# Altium schematic sheet 01 POWER.SchDoc
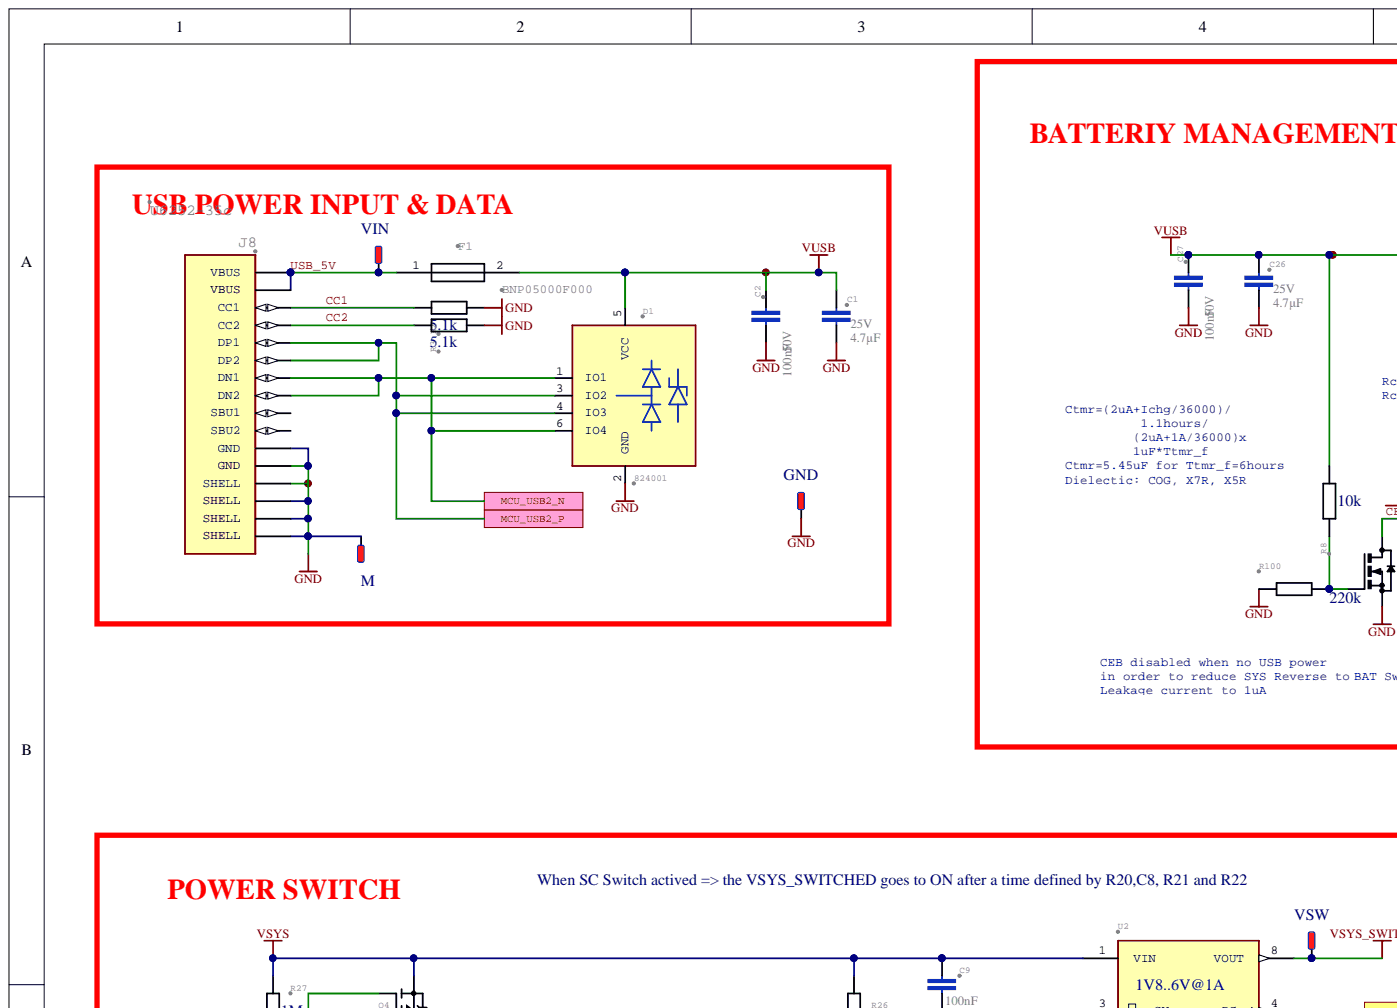
[diagram p0: 01 POWER.SchDoc, top left]
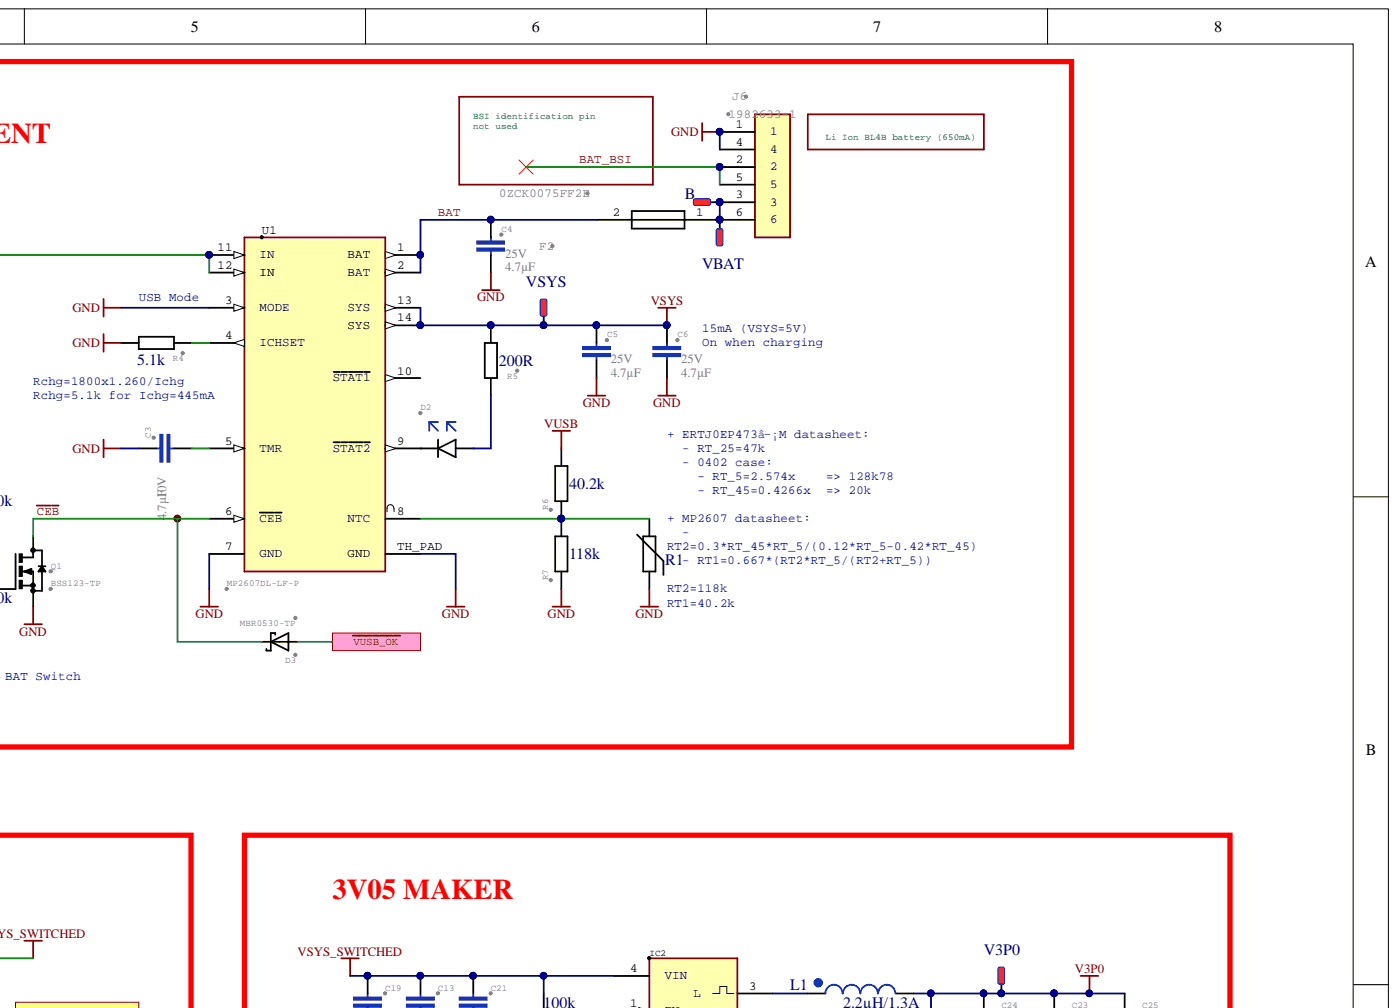
[diagram p1: 01 POWER.SchDoc, top right]
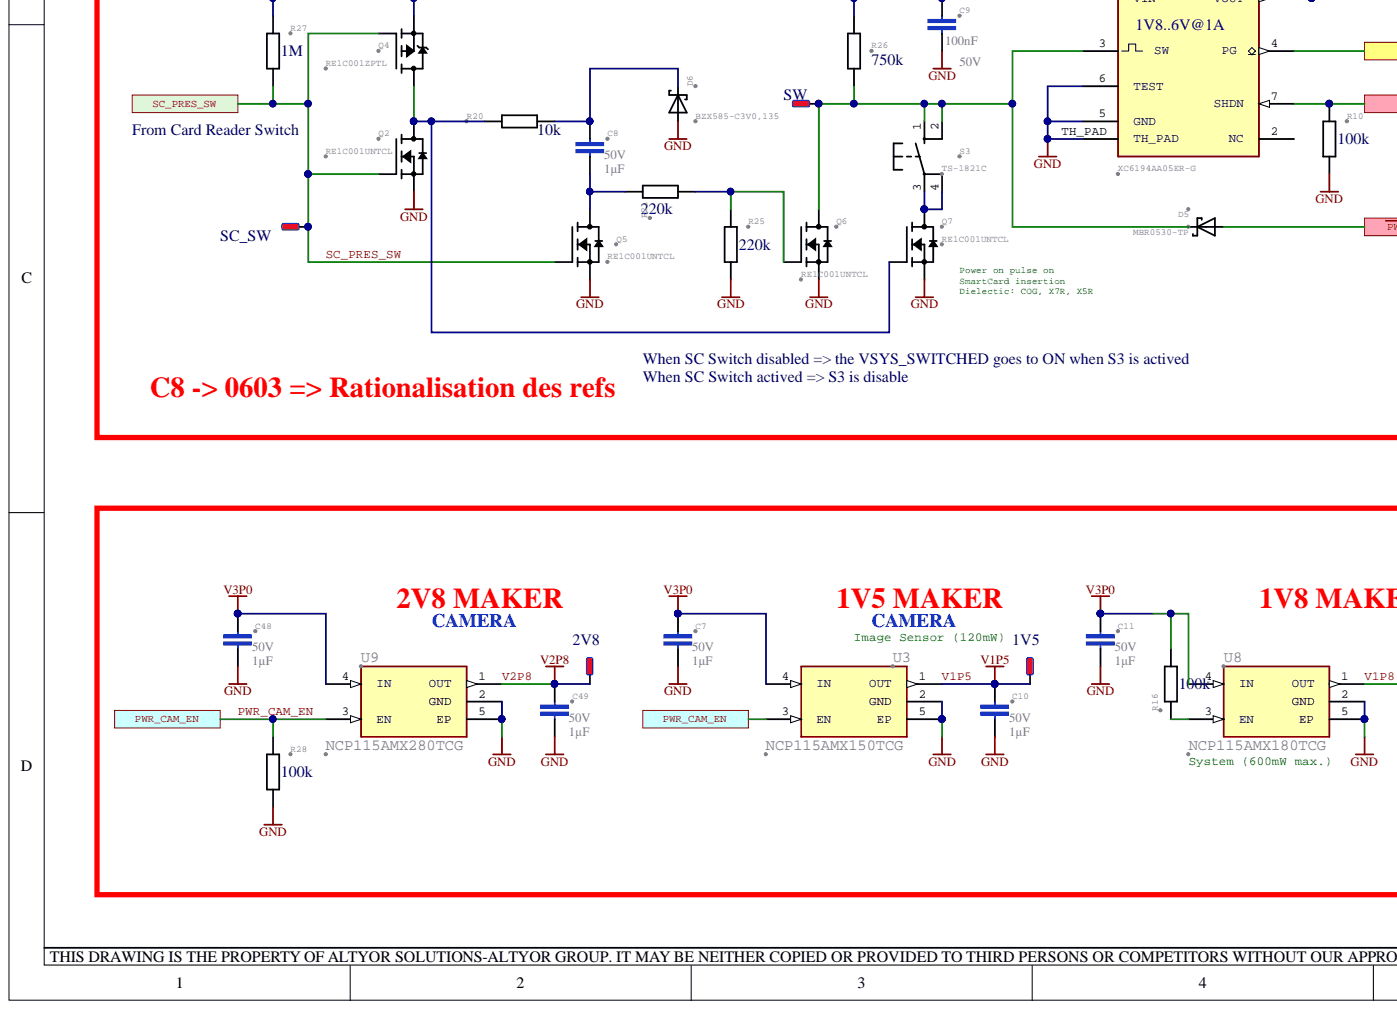
[diagram p2: 01 POWER.SchDoc, bottom left]
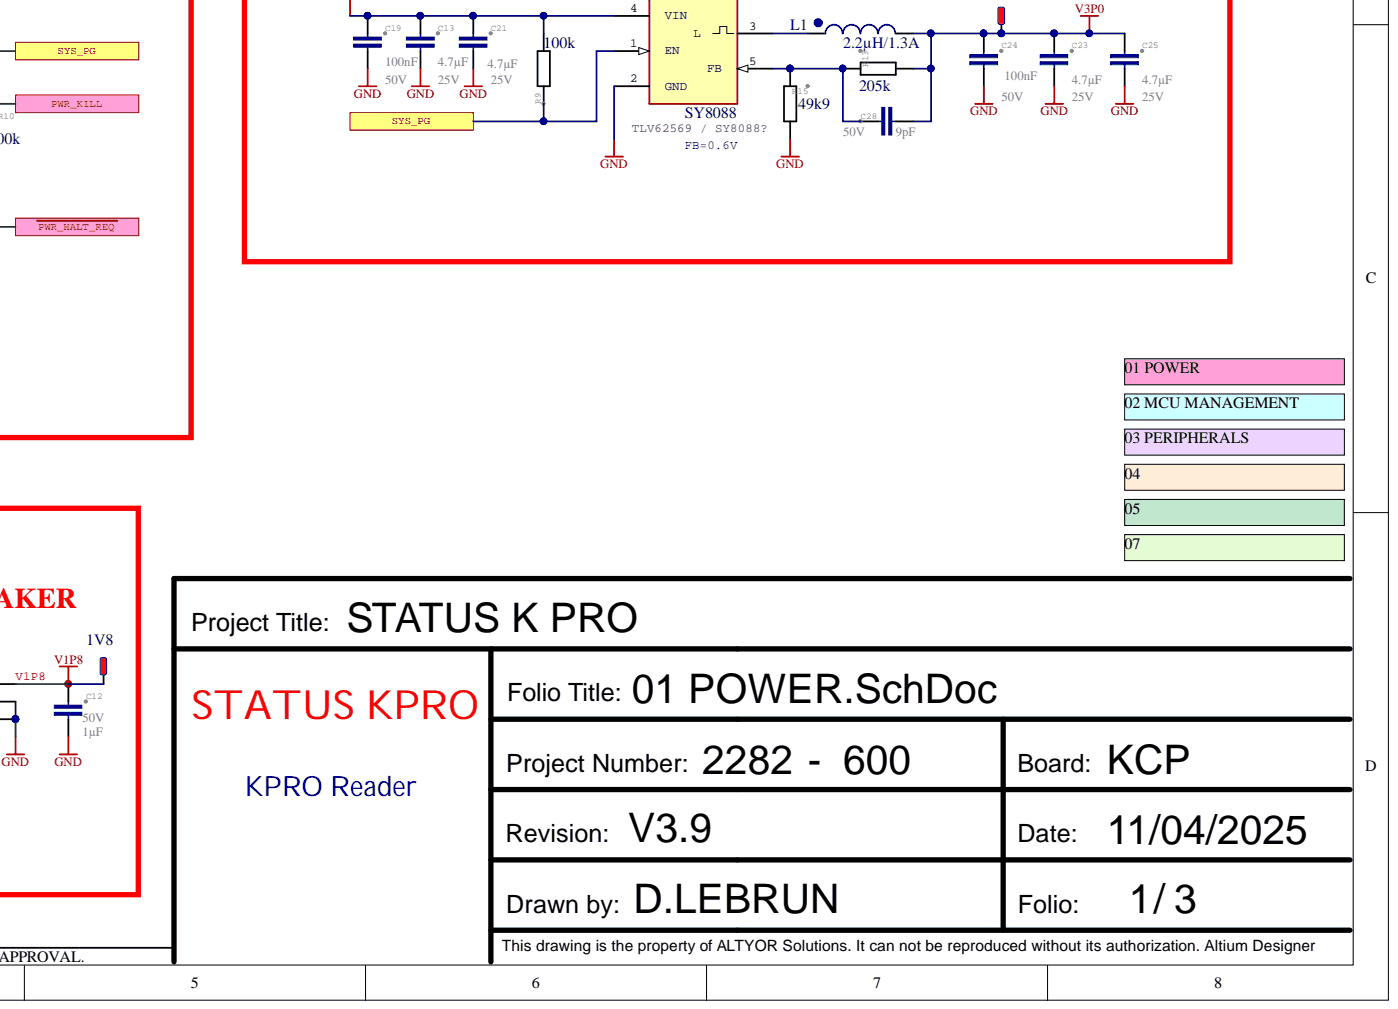
[diagram p3: 01 POWER.SchDoc, bottom right]

## Parts
1V5  TP  [TESTPOINT 1MM]  (value not drawn)  (no BOM)
1V8  TP  [TESTPOINT 1MM]  (value not drawn)  (no BOM)
2V8  TP  [TESTPOINT 1MM]  (value not drawn)  (no BOM)
B  TP  [PLAGE - ROUGE]  (value not drawn)  (no BOM)
C1  NAME: 4.7µF  VOLTAGE: 25V  [0805 - CAPACITOR]  (hidden comment: 0805X475M250CT)
C2  NAME: 100nF  VOLTAGE: 50V  [0402 - CAPACITOR]  (hidden comment: 0402B104K500CT)
C3  NAME: 4.7µF  VOLTAGE: 10V  [0402 - CAPACITOR]  (hidden comment: CC0402KRX5R6BB475)
C4  NAME: 4.7µF  VOLTAGE: 25V  [0805 - CAPACITOR]  (hidden comment: 0805X475M250CT)
C5  NAME: 4.7µF  VOLTAGE: 25V  [0805 - CAPACITOR]  (hidden comment: 0805X475M250CT)
C6  NAME: 4.7µF  VOLTAGE: 25V  [0805 - CAPACITOR]  (hidden comment: 0805X475M250CT)
C7  NAME: 1µF  VOLTAGE: 50V  [0603 - CAPACITOR]  (hidden comment: 0603X105K500CT)
C8  NAME: 1µF  VOLTAGE: 50V  [0603 - CAPACITOR]  (hidden comment: 0603X105K500CT)
C9  NAME: 100nF  VOLTAGE: 50V  [0402 - CAPACITOR]  (hidden comment: 0402B104K500CT)
C10  NAME: 1µF  VOLTAGE: 50V  [0603 - CAPACITOR]  (hidden comment: 0603X105K500CT)
C11  NAME: 1µF  VOLTAGE: 50V  [0603 - CAPACITOR]  (hidden comment: 0603X105K500CT)
C12  NAME: 1µF  VOLTAGE: 50V  [0603 - CAPACITOR]  (hidden comment: 0603X105K500CT)
C13  NAME: 4.7µF  VOLTAGE: 25V  [0805 - CAPACITOR]  (hidden comment: 0805X475M250CT)
C19  NAME: 100nF  VOLTAGE: 50V  [0402 - CAPACITOR]  (hidden comment: 0402B104K500CT)
C21  NAME: 4.7µF  VOLTAGE: 25V  [0805 - CAPACITOR]  (hidden comment: 0805X475M250CT)
C23  NAME: 4.7µF  VOLTAGE: 25V  [0805 - CAPACITOR]  (hidden comment: 0805X475M250CT)
C24  NAME: 100nF  VOLTAGE: 50V  [0402 - CAPACITOR]  (hidden comment: 0402B104K500CT)
C25  NAME: 4.7µF  VOLTAGE: 25V  [0805 - CAPACITOR]  (hidden comment: 0805X475M250CT)
C26  NAME: 4.7µF  VOLTAGE: 25V  [0805 - CAPACITOR]  (hidden comment: 0805X475M250CT)
C27  NAME: 100nF  VOLTAGE: 50V  [0402 - CAPACITOR]  (hidden comment: 0402B104K500CT)
C28  NAME: 9pF  VOLTAGE: 50V  [0402 - CAPACITOR]  (hidden comment: 0402N9R0B500CT)
C48  NAME: 1µF  VOLTAGE: 50V  [0603 - CAPACITOR]  (hidden comment: 0603X105K500CT)
C49  NAME: 1µF  VOLTAGE: 50V  [0603 - CAPACITOR]  (hidden comment: 0603X105K500CT)
D1  824001  [SOT23-6 0.95 Var AA]
D2  LR_Y8SF-U1V2-1  [OSRAM LRY8SFU1V21]  (value not drawn)
D3  MBR0530-TP  [SOD123 DIODE INC]
D5  MBR0530-TP  [SOD123 DIODE INC]
D6  BZX585-C3V0,135  [SOD523 TOSHIBA]
F1  BNP05000F000  [0805 - FUSE]
F2  0ZCK0075FF2E  [0805 - FUSE]
GND  TP  [TESTPOINT 1MM]  (value not drawn)  (no BOM)
IC2  NAME: SY8088  [SOT23-5 0.95 Var AA]  (hidden comment: SY8088IAAC)
J6  1982633-1  [TE 19826331]
J8  U6252-35c  [ANTENK U6252-35C]
L1  NAME: 2.2µH/1.3A  [CHILISON BWF00252012]  (hidden comment: BWVF002520122R2M00)
M  TP  [PLAGE - NOIRE]  (value not drawn)  (no BOM)
Q1  BSS123-TP  [SOT23-VAR AB]
Q2  RE1C001UNTCL  [SOT-416-3]
Q4  RE1C001ZPTL  [SOT-416-3]
Q5  RE1C001UNTCL  [SOT-416-3]
Q6  RE1C001UNTCL  [SOT-416-3]
Q7  RE1C001UNTCL  [SOT-416-3]
R1  ERT-J0EP473GM  [0402 - RESISTOR]  (value not drawn)
R2  NAME: 5.1k  [0402 - RESISTOR]  (hidden comment: RC0402FR-075K1L)
R3  NAME: 5.1k  [0402 - RESISTOR]  (hidden comment: RC0402FR-075K1L)
R4  NAME: 5.1k  [0402 - RESISTOR]  (hidden comment: RC0402FR-075K1L)
R5  NAME: 200R  [0402 - RESISTOR]  (hidden comment: RC0402FR-07200RL)
R6  NAME: 40.2k  [0402 - RESISTOR]  (hidden comment: RC0402FR-0740K2L)
R7  NAME: 118k  [0402 - RESISTOR]  (hidden comment: RC0402FR-07118KL)
R8  NAME: 10k  [0402 - RESISTOR]  (hidden comment: RC0402FR-0710KL)
R9  NAME: 100k  [0402 - RESISTOR]  (hidden comment: RC0402FR-07100KL)
R10  NAME: 100k  [0402 - RESISTOR]  (hidden comment: RC0402FR-07100KL)
R13  NAME: 205k  [0402 - RESISTOR]  (hidden comment: RC0402FR-07205KL)
R15  NAME: 49k9  [0402 - RESISTOR]  (hidden comment: RC0402FR-0749K9L)
R16  NAME: 100k  [0402 - RESISTOR]  (hidden comment: RC0402FR-07100KL)
R20  NAME: 10k  [0402 - RESISTOR]  (hidden comment: RC0402FR-0710KL)
R21  NAME: 220k  [0402 - RESISTOR]  (hidden comment: RC0402FR-07220KL)
R25  NAME: 220k  [0402 - RESISTOR]  (hidden comment: RC0402FR-07220KL)
R26  NAME: 750k  [0402 - RESISTOR]  (hidden comment: RC0402FR-07750KL)
R27  NAME: 1M  [0402 - RESISTOR]  (hidden comment: RC0201FR-071ML)
R28  NAME: 100k  [0402 - RESISTOR]  (hidden comment: RC0402FR-07100KL)
R100  NAME: 220k  [0402 - RESISTOR]  (hidden comment: RC0402FR-07220KL)
S3  TS-1821C  [SONG TS1821C]
SC_SW  TP  [TESTPOINT 1MM]  (value not drawn)  (no BOM)
SW  TP  [TESTPOINT 1MM]  (value not drawn)  (no BOM)
U1  MP2607DL-LF-P  [QFN14-0.5-3s4]
U2  XC6194AA05ER-G  [TOREX USP-8B06]
U3  NCP115AMX150TCG  [ON SEMI NCV8160AMX330TBG]
U8  NCP115AMX180TCG  [ON SEMI NCV8160AMX330TBG]
U9  NCP115AMX280TCG  [ON SEMI NCV8160AMX330TBG]
V3P0  TP  [TESTPOINT 1MM]  (value not drawn)  (no BOM)
VBAT  TP  [TESTPOINT 1MM]  (value not drawn)  (no BOM)
VIN  TP  [TESTPOINT 1MM]  (value not drawn)  (no BOM)
VSW  TP  [TESTPOINT 1MM]  (value not drawn)  (no BOM)
VSYS  TP  [TESTPOINT 1MM]  (value not drawn)  (no BOM)

## Ports
MCU_USB2_N, MCU_USB2_P, PWR_CAM_EN, PWR_KILL, SC_PRES_SW, SYS_PG, ~{PWR_HALT_REQ}, ~{VUSB_OK}

## Nets
GND: C1.2 C2.2 C3.1 C4.2 C5.2 C6.2 C7.2 C9.2 C10.2 C11.2 C12.2 C13.2 C19.2 C21.2 C23.2 C24.2 C25.2 C26.2 C27.2 C48.2 C49.2 D1.2(GND) D6.A GND.1 IC2.2(GND) J6.1 J6.4 J8.A1_B12(GND) J8.B1_A12(GND) J8.S1(SHELL) J8.S2(SHELL) J8.S3(SHELL) J8.S4(SHELL) M.1 Q1.2 Q2.2 Q5.2 Q6.2 Q7.2 R1.1 R2.1 R3.1 R4.2 R7.1 R10.1 R15.1 R25.1 R28.1 R100.1 U1.3(MODE) U1.7(GND) U1.TH_PAD(GND) U2.5(GND) U2.6(TEST) U2.TH_PAD U3.2(GND) U3.5(EP) U8.2(GND) U8.5(EP) U9.2(GND) U9.5(EP)
V3P0: C7.1 C11.1 C23.1 C24.1 C25.1 C28.2 C48.1 L1.2 R13.1 R16.1 U3.4(IN) U8.4(IN) U9.4(IN) V3P0.1
VSYS: C5.1 C6.1 C9.1 Q4.2 R5.1 R26.2 R27.2 U1.13(SYS) U1.14(SYS) U2.1(VIN) VSYS.1
VSYS_SWITCHED: C13.1 C19.1 C21.1 IC2.4(VIN) R9.1 U2.8(VOUT) VSW.1
VUSB: C1.1 C2.1 C26.1 C27.1 D1.5(VCC) F1.2 R6.2 R8.2 U1.11(IN) U1.12(IN)
BAT: C4.1 F2.2 U1.1(BAT) U1.2(BAT)
BAT_BSI: J6.2 J6.5
~{CEB}: D3.C Q1.3 U1.6(CEB)
CC1: J8.A5(CC1) R2.2
CC2: J8.B5(CC2) R3.2
MCU_USB2_N: D1.1(IO1) D1.6(IO4) J8.A7(DN1) J8.B7(DN2) ; port MCU_USB2_N
MCU_USB2_P: D1.3(IO2) D1.4(IO3) J8.A6(DP1) J8.B6(DP2) ; port MCU_USB2_P
~{PWR_HALT_REQ}: D5.A ; port ~{PWR_HALT_REQ}
PWR_CAM_EN: R28.2 U3.3(EN) U9.3(EN) ; port PWR_CAM_EN
PWR_KILL: R10.2 U2.7(SHDN) ; port PWR_KILL
SC_PRES_SW: Q2.1 Q4.1 Q5.1 R27.1 SC_SW.1 ; port SC_PRES_SW
SYS_PG: IC2.1(EN) R9.2 U2.4(PG) ; port SYS_PG
USB_5V: F1.1 J8.A4_B9(VBUS) J8.B4_A9(VBUS) VIN.1
V1P5: 1V5.1 C10.1 U3.1(OUT)
V1P8: 1V8.1 C12.1 U8.1(OUT)
V2P8: 2V8.1 C49.1 U9.1(OUT)
~{VUSB_OK}: D3.A ; port ~{VUSB_OK}
NetB_1: B.1 F2.1 J6.3 J6.6 VBAT.1
NetC3_2: C3.2 U1.5(TMR)
NetC8_1: C8.1 D6.C R20.2
NetC8_2: C8.2 Q5.3 R21.2
NetC28_1: C28.1 IC2.5(FB) R13.2 R15.2
NetD2_1: D2.1 U1.9(STAT2)
NetD2_2: D2.2 R5.2
NetD5_C: D5.C Q6.3 R26.1 S3.1 S3.2 SW.1 U2.3
NetIC2_3: IC2.3 L1.1
NetQ1_1: Q1.1 R8.1 R100.2
NetQ2_3: Q2.3 Q4.3 Q7.1 R20.1
NetQ6_1: Q6.1 R21.1 R25.2
NetQ7_3: Q7.3 S3.3 S3.4
NetR1_2: R1.2 R6.1 R7.2 U1.8(NTC)
NetR4_1: R4.1 U1.4(ICHSET)
NetR16_2: R16.2 U8.3(EN)

Not connected: J8 A8(SBU1) B8(SBU2); U1 10(STAT1); U2 2(NC)

## Library data of the parts
1V5 1V8 2V8 B GND M SC_SW SW V3P0 VBAT VIN VSW VSYS = TP 1.27mm: Pointe de test 1.27mm; ERP CODE: 10-*; ERP SF CODE: 16; GENERIC: False; NAME: 1.27; TMAX: N/A; TMIN: N/A; Library Name: KCP-2282-600.SchLib
C1 C4-C6 C13 C21 C23 C25 C26 = C-EU-C4.7µF-X5R-6.3V-0805: CAP X5R 4.7µF 25V ±10% 0805; MANUFACTURER: WALSIN; ARTICLE CODE: MSR 791-0805X475M250CT; ERP CODE: 10-*; ERP SF CODE: 4; GENERIC: True; TMAX: 125; TMIN: -55; Component Kind: Standard; Library Name: KCP-2282-600.SchLib
C2 C9 C19 C24 C27 = C-EU-C100NF-X7R-16V-0402: CAP X7R 100nF 50V ±10% 0402; MANUFACTURER: WALSIN; ARTICLE CODE: MSR 791-0402B104K500CT; ERP CODE: 10-*; ERP SF CODE: 4; GENERIC: True; TMAX: 125; TMIN: -55; Component Kind: Standard; Library Name: KCP-2282-600.SchLib
C3 = C-EU-C5UF-X7R-6.3V-0402: CAP X5R 4.7µF 10V ±10% 0402; MANUFACTURER: YAGEO; ARTICLE CODE: MSR 603-CC0402KR5R6BB475; ERP CODE: 10-*; ERP SF CODE: 4; GENERIC: True; TMAX: 125; TMIN: -55; Component Kind: Standard; Library Name: KCP-2282-600.SchLib
C7 C8 C10-C12 C48 C49 = C-EU-C1µF-X5R-6.3V-0603: CAP X5R 1µF 50V ±10% 0603; MANUFACTURER: WALSIN; ARTICLE CODE: MSR 791-0603X105K500CT; ERP CODE: 10-*; ERP SF CODE: 4; GENERIC: True; TMAX: 125; TMIN: -55; Component Kind: Standard; Library Name: KCP-2282-600.SchLib
C28 = C-EU-C9PF-C0G-50V-0402: CAP COG 9pF 50V ±0.1pF 0402; MANUFACTURER: WALSIN; ARTICLE CODE: MSR 791-0402N220F500CT; ERP CODE: 10-*; ERP SF CODE: 4; GENERIC: True; TMAX: 125; TMIN: -55; Component Kind: Standard; Library Name: KCP-2282-600.SchLib
D1 = 824001: diode TVS, 82400 Series, Bidirectionelle; MANUFACTURER: Würth; ARTICLE CODE: MSR 710-824001; ERP CODE: 10-*; GENERIC: False; NAME: ESD 5V; TMAX: 85; TMIN: -55; Component Kind: Standard; Library Name: KCP-2282-600.SchLib
D2 = LR_Y8SF-U1V2-1: Osram Opto Micro SIDELED Series Red LED, 120    Source:  Datasheet; ARTICLE CODE: MSR 720-LRY8SFU1V21; ERP CODE: 10-*; ERP SF CODE: 5; GENERIC: Flase; NAME: LR Y8SF-U1V2-1; TMAX: 100; TMIN: -40; Component Kind: Standard; MANUFACTURER: OSRAM; Library Name: KCP-2282-600.SchLib
D3 = MBR0530T1G: Schottky Rectifier, 30 V, 500 mA, Single; MANUFACTURER: ON SEMI; ARTICLE CODE: MSR 833-MBR0530-TP; ERP CODE: MBR0530-*; GENERIC: False; TMAX: -65; TMIN: 125; Component Kind: Standard; NAME: MBR0530; Library Name: KCP-2282-600.SchLib
D5 = MBR0530T1G: Schottky Rectifier, 30 V, 500 mA, Single; MANUFACTURER: ON SEMI; ARTICLE CODE: MSR 863-MBR0530-TP; ERP CODE: MBR0530-*; GENERIC: False; TMAX: -65; TMIN: 125; Component Kind: Standard; NAME: MBR0530; Library Name: KCP-2282-600.SchLib
D6 = MBR0530T1G: Zener 3V, 300mW - SOD 523-3; MANUFACTURER: NXP; ARTICLE CODE: MSR 771-BZX585-C3V0135; GENERIC: False; TMAX: -65; TMIN: 150; Component Kind: Standard; NAME: 3V; Library Name: KCP-2282-600.SchLib
F1 = 0ZCK0050FF2E: FUSE 500mA 6V 0805; MANUFACTURE_CND: '0ZCK0050FF2E/0402L050SLKR; MANUFACTURER: JNHONGBAO; ARTICLE CODE: 530-0ZCK0050FF2E; ERP CODE: 10-*; GENERIC: False; NAME: 500mA@32V; TMAX: 85; TMIN: -40; Component Kind: Standard; ERP SF CODE: BNP05000F000; Library Name: KCP-2282-600.SchLib
F2 = 0ZCK0075FF2E: PTC Resettable Fuse 0.75A(hold) 1.5A(trip) 6VDC 40A 0.6W 0.2s 0.09Ohm SMD Solder Pad 0805 T/R Automotive   Source:  Datasheet; MANUFACTURER: Bel Circuit Protection; ARTICLE CODE: MSR 530-0ZCK0075FF2E; ERP CODE: 10-*; GENERIC: False; NAME: 1.5A@6V; TMAX: 85; TMIN: -40; Component Kind: Standard; Library Name: KCP-2282-600.SchLib
IC2 = SILERGY SI8088IAAC: DCDC 2V5..5V5  50µA 1V8..3V3; ARTICLE CODE: LCSC C479072; GENERIC: False; TMAX: 85; TMIN: -40; Component Kind: Standard; MANUFACTURER: SILERGY; Library Name: KCP-2282-600.SchLib
J6 = 1982633-1: Connecteurs carte Ã  carte et mezzanine BATTERY CONN 3 POSITION; MANUFACTURER: TE Connectivity; ARTICLE CODE: MSR 571-1982633-1; ERP CODE: 10-*; GENERIC: False; NAME: 3pts; TMAX: 80; TMIN: -20; Component Kind: Standard; Library Name: KCP-2282-600.SchLib
J8 = ANTENK U6252-35c: Connecteurs USB USB C Rec 16P 3u" Mid Mnt 1.6mm O-set SMT L = 6.5mm S-Spring; MANUFACTUR_CND: GSB1C4621DS1HR; MANUFACTURER: ANTENK; ERP CODE: 10-*; GENERIC: False; NAME: USB-C; TMAX: 85; TMIN: -25; Component Kind: Standard; Library Name: KCP-2282-600.SchLib
L1 = CDRH5D28NP-100NC: IND 2.2µH, 20%, 1.8A 68mOhm 1MHz - 2.5x2; MANUFACTURER: CHILISIN; ARTICLE CODE: LCSC C2838263; ERP CODE: 10-*; ERP SF CODE: 07; GENERIC: False; Tmax: +125; Tmin: -40; Component Kind: Standard; Library Name: KCP-2282-600.SchLib
Q1 = BSS123: MOS N 100V 170mA Rds6R - SOT23; MANUFACTURER: MCC; ARTICLE CODE: MSR 889-BSS123-TP; GENERIC: False; TMAX: 150; TMIN: -55; Component Kind: Standard; NAME: BSS123-TP; Library Name: KCP-2282-600.SchLib
Q2 Q5-Q7 = RE1C001UNTCL: MOS N 20V 100mA Rds3.5R; MANUFACTURER: ROHM SEMI; ARTICLE CODE: MSR 755-RE1C001UNTCL; ERP CODE: 10-*; GENERIC: False; TMAX: 150; TMIN: -55; Component Kind: Standard; NAME: RE1C001UNTCL; Library Name: KCP-2282-600.SchLib
Q4 = RE1C001ZPTL: MOS P 20V 100mA Rds3.8R; MANUFACTURER: ROHM SEMI; ARTICLE CODE: MSR 755-RE1C001ZPTL; GENERIC: False; TMAX: 150; TMIN: -55; Component Kind: Standard; NAME: RE1C001ZPTL; Library Name: KCP-2282-600.SchLib
R1 = ERT-J0EP473GM: CTN 47k 2% B(25/50) 4050K 0/+1% - 0402; MANUFACTURER: PANASONIC; ARTICLE CODE: MSR 667-ERT-J0EP473GM; ERP CODE: 10-*; ERP SF CODE: 07; GENERIC: False; NAME: NTC-47k; Tmax: +125; Tmin: -40; Component Kind: Standard; Library Name: KCP-2282-600.SchLib
R2 R3 = R-EU-R5.1K-1%-0402: RES 5.1k 63mW 1% 0402; MANUFACTURER: YAGEO; ARTICLE CODE: MSR 603-RC0402FR-075K1L; GENERIC: True; TMAX: 150; TMIN: -55; Component Kind: Standard; Library Name: KCP-2282-600.SchLib
R4 = R-EU-R4.53K-1%-0402: RES 5.1k 63mW 1% 0402; MANUFACTURER: YAGEO; ARTICLE CODE: MSR 603-RC0402FR-075K1L; GENERIC: True; TMAX: 150; TMIN: -55; Component Kind: Standard; Library Name: KCP-2282-600.SchLib
R5 = R-EU-R200-1%-0402: RES 200R 63mW 1% 0402; MANUFACTURER: YAGEO; ARTICLE CODE: MSR 603-RC0402FR-07200rL; GENERIC: True; TMAX: 150; TMIN: -55; Component Kind: Standard; Library Name: KCP-2282-600.SchLib
R6 = R-EU-R40.2K-1%-0402: RES 40.2k 63mW 1% 0402; MANUFACTURER: YAGEO; ARTICLE CODE: MSR 603-RC0402FR-0740K2L; GENERIC: True; TMAX: 150; TMIN: -55; Component Kind: Standard; Library Name: KCP-2282-600.SchLib
R7 = R-EU-R118K-1%-0402: RES 118k 63mW 1% 0402; MANUFACTURER: YAGEO; ARTICLE CODE: MSR 603-RC0402FR-07118KL; GENERIC: True; TMAX: 150; TMIN: -55; Component Kind: Standard; Library Name: KCP-2282-600.SchLib
R8 R20 = R-EU-R10K-1%-0402: RES 10k 63mW 1% 0402; MANUFACTURER: YAGEO; ARTICLE CODE: MSR 603-RC0402FR-0710KL; GENERIC: True; TMAX: 150; TMIN: -55; Component Kind: Standard; Library Name: KCP-2282-600.SchLib
R9 R10 R16 R28 = R-EU-R100K-1%-0402: RES 100k 63mW 1% 0402; MANUFACTURER: YAGEO; ARTICLE CODE: MSR 603-RC0402FR-07100KL; GENERIC: True; TMAX: 150; TMIN: -55; Component Kind: Standard; Library Name: KCP-2282-600.SchLib
R13 = R-EU-R100K-1%-0402: RES 205k 63mW 1% 0402; MANUFACTURER: YAGEO; ARTICLE CODE: MSR 603-RC0402FR-07205KL; GENERIC: True; TMAX: 150; TMIN: -55; Component Kind: Standard; Library Name: KCP-2282-600.SchLib
R15 = R-EU-R100K-1%-0402: RES 49k9 63mW 1% 0402; MANUFACTURER: YAGEO; ARTICLE CODE: MSR 603-RC0402FR-0749K9L; GENERIC: True; TMAX: 150; TMIN: -55; Component Kind: Standard; Library Name: KCP-2282-600.SchLib
R21 R25 R100 = R-EU-R220K-1%-0402: RES 220k 63mW 1% 0402; MANUFACTURER: YAGEO; ARTICLE CODE: MSR 603-RC0402FR-07220KL; GENERIC: True; TMAX: 150; TMIN: -55; Component Kind: Standard; Library Name: KCP-2282-600.SchLib
R26 = R-EU-R750K-1%-0402: RES 750k 63mW 1% 0402; MANUFACTURER: YAGEO; ARTICLE CODE: MSR 603-RC0402FR-07750KL; GENERIC: True; TMAX: 150; TMIN: -55; Component Kind: Standard; Library Name: KCP-2282-600.SchLib
R27 = R-EU-R1M-1%-0402: RES 1M 63mW 1% 0402; MANUFACTURER: YAGEO; ARTICLE CODE: MSR 603-RC0201FR-071ML; GENERIC: True; TMAX: 150; TMIN: -55; Component Kind: Standard; Library Name: KCP-2282-600.SchLib
S3 = 434331013822: Interrupteurs de contact WS-TASU SMD Switch 3.5x2.9 x1.35mm; MANUFACTURER: SONG; ERP CODE: 10-*; GENERIC: False; TMAX: 85; TMIN: -40; Component Kind: Standard; MANUFACTURE_CND: 434331013822; NAME: 434331013822; Library Name: KCP-2282-600.SchLib
U1 = MP2607DL-LF-P: Li + LINEAR Charger WithSystem Power Path Management; MANUFACTURER: MPS; ARTICLE CODE: MSR 946-MP2607DLLFP; ERP CODE: 10-*; GENERIC: False; TMAX: 125; TMIN: -40; Component Kind: Standard; NAME: MP2607DL-LF-P; Library Name: KCP-2282-600.SchLib
U2 = XC6194AA05ER-G: Power saving Push Button Load switch; MANUFACTURER: TOREX; ARTICLE CODE: MSR 865-XC6194AA05ER-G; ERP CODE: 10-*; GENERIC: False; NAME: XC6192AA05ER-G; TMAX: 85; TMIN: -40; Component Kind: Standard; Library Name: KCP-2282-600.SchLib
U3 = NCP115AMX150TCG: LDO Regulator - High PSRR - 300mA - Input Voltage 1.7V/5.5V - Ouput Voltage 1.5V; MANUFACTURER: ON SEMI; ARTICLE CODE: MSR 863-NCP115AMX150TCG; ERP CODE: 10-*; ERP SF CODE: 11; GENERIC: False; NAME: NCP115 1V5; TMAX: 85; TMIN: -40; Component Kind: Standard; Library Name: KCP-2282-600.SchLib
U8 = NCP115AMX180TCG: LDO Regulator - High PSRR - 300mA - Input Voltage 1.7V/5.5V - Ouput Voltage 1.8V; MANUFACTURER: ON SEMI; ARTICLE CODE: MSR 863-NCP115AMX180TCG; ERP CODE: 10-*; ERP SF CODE: 11; GENERIC: Flase; NAME: NCP115 1V8; TMAX: 85; TMIN: -40; Component Kind: Standard; Library Name: KCP-2282-600.SchLib
U9 = NCP115AMX280TCG: LDO Regulator - High PSRR - 300mA - Input Voltage 1.7V/5.5V - Ouput Voltage 2.8V; MANUFACTURER: ON SEMI; ARTICLE CODE: MSR 863-NCP115AMX280TCG; ERP CODE: 10-*; ERP SF CODE: 11; GENERIC: Flase; NAME: NCP115 2V8; TMAX: 85; TMIN: -40; Component Kind: Standard; Library Name: KCP-2282-600.SchLib
